# Altium schematic sheet pmic_right.SchDoc(pmic_right)
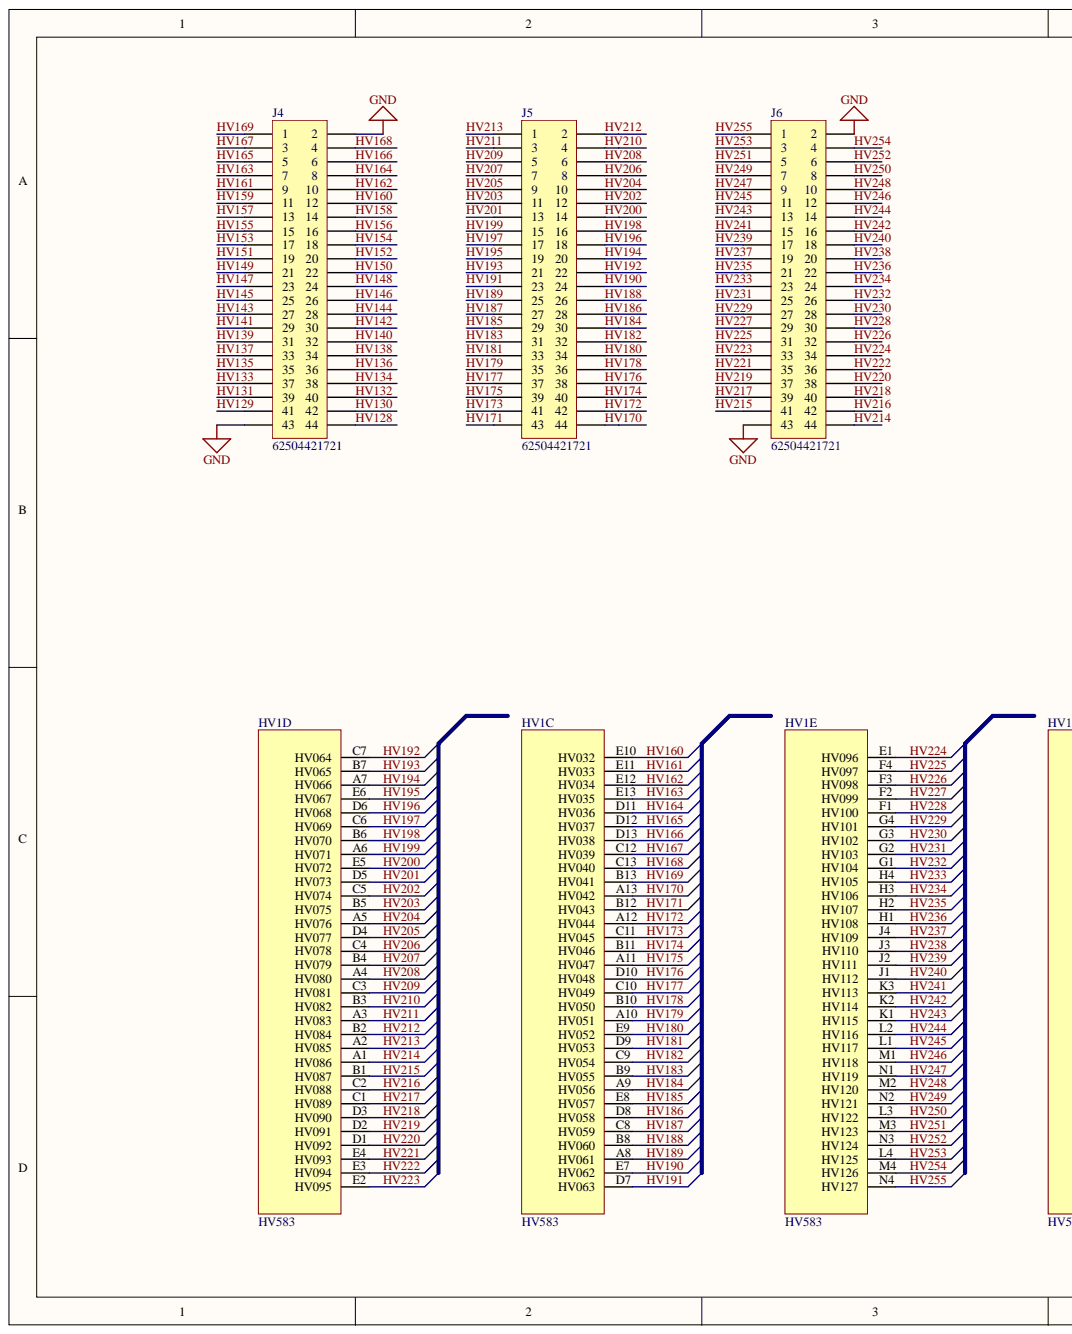
[diagram p0: pmic_right.SchDoc, left]
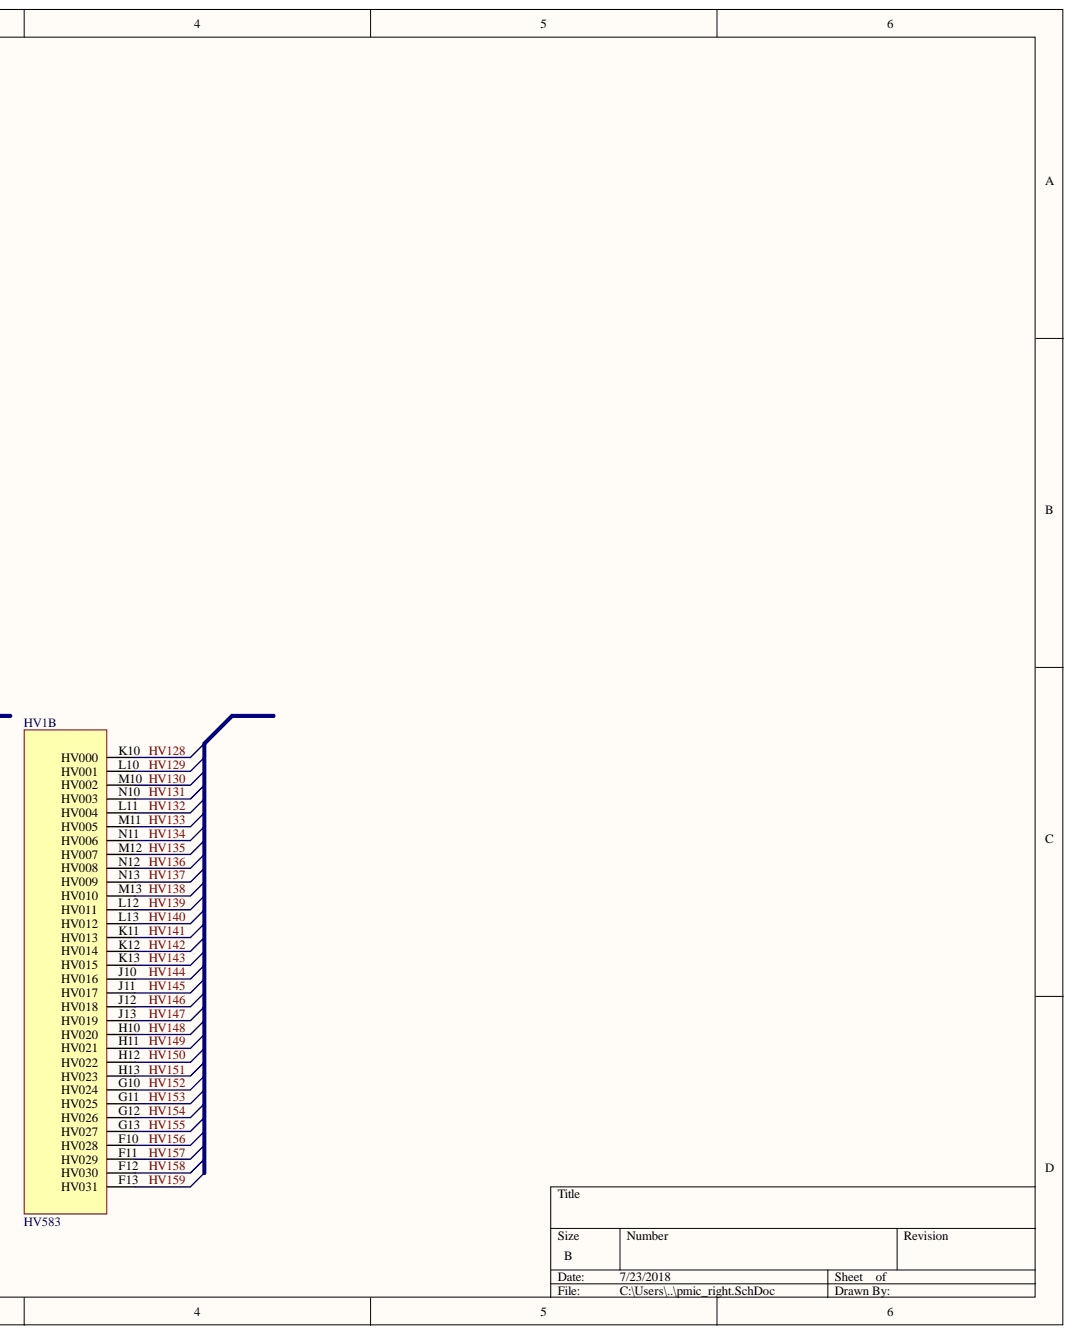
[diagram p1: pmic_right.SchDoc, right]

## Parts
HV1  HV583  [BGA169x13_0.26balls]  (parts B,C,D,E)
J4  62504421721  [62504421721]
J5  62504421721  [62504421721]
J6  62504421721  [62504421721]

## Nets
GND: J4.2 J4.43 J6.2 J6.43
HV128: HV1.K10(HV000) J4.44
HV129: HV1.L10(HV001) J4.41
HV130: HV1.M10(HV002) J4.42
HV131: HV1.N10(HV003) J4.39
HV132: HV1.L11(HV004) J4.40
HV133: HV1.M11(HV005) J4.37
HV134: HV1.N11(HV006) J4.38
HV135: HV1.M12(HV007) J4.35
HV136: HV1.N12(HV008) J4.36
HV137: HV1.N13(HV009) J4.33
HV138: HV1.M13(HV010) J4.34
HV139: HV1.L12(HV011) J4.31
HV140: HV1.L13(HV012) J4.32
HV141: HV1.K11(HV013) J4.29
HV142: HV1.K12(HV014) J4.30
HV143: HV1.K13(HV015) J4.27
HV144: HV1.J10(HV016) J4.28
HV145: HV1.J11(HV017) J4.25
HV146: HV1.J12(HV018) J4.26
HV147: HV1.J13(HV019) J4.23
HV148: HV1.H10(HV020) J4.24
HV149: HV1.H11(HV021) J4.21
HV150: HV1.H12(HV022) J4.22
HV151: HV1.H13(HV023) J4.19
HV152: HV1.G10(HV024) J4.20
HV153: HV1.G11(HV025) J4.17
HV154: HV1.G12(HV026) J4.18
HV155: HV1.G13(HV027) J4.15
HV156: HV1.F10(HV028) J4.16
HV157: HV1.F11(HV029) J4.13
HV158: HV1.F12(HV030) J4.14
HV159: HV1.F13(HV031) J4.11
HV160: HV1.E10(HV032) J4.12
HV161: HV1.E11(HV033) J4.9
HV162: HV1.E12(HV034) J4.10
HV163: HV1.E13(HV035) J4.7
HV164: HV1.D11(HV036) J4.8
HV165: HV1.D12(HV037) J4.5
HV166: HV1.D13(HV038) J4.6
HV167: HV1.C12(HV039) J4.3
HV168: HV1.C13(HV040) J4.4
HV169: HV1.B13(HV041) J4.1
HV170: HV1.A13(HV042) J5.44
HV171: HV1.B12(HV043) J5.43
HV172: HV1.A12(HV044) J5.42
HV173: HV1.C11(HV045) J5.41
HV174: HV1.B11(HV046) J5.40
HV175: HV1.A11(HV047) J5.39
HV176: HV1.D10(HV048) J5.38
HV177: HV1.C10(HV049) J5.37
HV178: HV1.B10(HV050) J5.36
HV179: HV1.A10(HV051) J5.35
HV180: HV1.E9(HV052) J5.34
HV181: HV1.D9(HV053) J5.33
HV182: HV1.C9(HV054) J5.32
HV183: HV1.B9(HV055) J5.31
HV184: HV1.A9(HV056) J5.30
HV185: HV1.E8(HV057) J5.29
HV186: HV1.D8(HV058) J5.28
HV187: HV1.C8(HV059) J5.27
HV188: HV1.B8(HV060) J5.26
HV189: HV1.A8(HV061) J5.25
HV190: HV1.E7(HV062) J5.24
HV191: HV1.D7(HV063) J5.23
HV192: HV1.C7(HV064) J5.22
HV193: HV1.B7(HV065) J5.21
HV194: HV1.A7(HV066) J5.20
HV195: HV1.E6(HV067) J5.19
HV196: HV1.D6(HV068) J5.18
HV197: HV1.C6(HV069) J5.17
HV198: HV1.B6(HV070) J5.16
HV199: HV1.A6(HV071) J5.15
HV200: HV1.E5(HV072) J5.14
HV201: HV1.D5(HV073) J5.13
HV202: HV1.C5(HV074) J5.12
HV203: HV1.B5(HV075) J5.11
HV204: HV1.A5(HV076) J5.10
HV205: HV1.D4(HV077) J5.9
HV206: HV1.C4(HV078) J5.8
HV207: HV1.B4(HV079) J5.7
HV208: HV1.A4(HV080) J5.6
HV209: HV1.C3(HV081) J5.5
HV210: HV1.B3(HV082) J5.4
HV211: HV1.A3(HV083) J5.3
HV212: HV1.B2(HV084) J5.2
HV213: HV1.A2(HV085) J5.1
HV214: HV1.A1(HV086) J6.44
HV215: HV1.B1(HV087) J6.41
HV216: HV1.C2(HV088) J6.42
HV217: HV1.C1(HV089) J6.39
HV218: HV1.D3(HV090) J6.40
HV219: HV1.D2(HV091) J6.37
HV220: HV1.D1(HV092) J6.38
HV221: HV1.E4(HV093) J6.35
HV222: HV1.E3(HV094) J6.36
HV223: HV1.E2(HV095) J6.33
HV224: HV1.E1(HV096) J6.34
HV225: HV1.F4(HV097) J6.31
HV226: HV1.F3(HV098) J6.32
HV227: HV1.F2(HV099) J6.29
HV228: HV1.F1(HV100) J6.30
HV229: HV1.G4(HV101) J6.27
HV230: HV1.G3(HV102) J6.28
HV231: HV1.G2(HV103) J6.25
HV232: HV1.G1(HV104) J6.26
HV233: HV1.H4(HV105) J6.23
HV234: HV1.H3(HV106) J6.24
HV235: HV1.H2(HV107) J6.21
HV236: HV1.H1(HV108) J6.22
HV237: HV1.J4(HV109) J6.19
HV238: HV1.J3(HV110) J6.20
HV239: HV1.J2(HV111) J6.17
HV240: HV1.J1(HV112) J6.18
HV241: HV1.K3(HV113) J6.15
HV242: HV1.K2(HV114) J6.16
HV243: HV1.K1(HV115) J6.13
HV244: HV1.L2(HV116) J6.14
HV245: HV1.L1(HV117) J6.11
HV246: HV1.M1(HV118) J6.12
HV247: HV1.N1(HV119) J6.9
HV248: HV1.M2(HV120) J6.10
HV249: HV1.N2(HV121) J6.7
HV250: HV1.L3(HV122) J6.8
HV251: HV1.M3(HV123) J6.5
HV252: HV1.N3(HV124) J6.6
HV253: HV1.L4(HV125) J6.3
HV254: HV1.M4(HV126) J6.4
HV255: HV1.N4(HV127) J6.1
N00375:
N00376:
N00377:
N00378:

## Library data of the parts
HV1 = HV583: 128bit high voltage shift register; Library Name: Microchip_HV58x.SchLib; Component Kind: Standard
J4-J6 = 62504421721: WR-BHD 2.00mm Male Angled Box Header, 44p; Pin Number: 44; Published Date: June 2017; Pitch: 2.00 mm; Part Number: 62504421721; Library Name: ALTIUM-BHD_2_00_MALE_ANGLED_BOX_HEADER_6250XX21721-rev17a.IntLib; ComponentLink2URL: http://katalog.we-online.de/em/datasheet/6250xx21721.pdf; ComponentLink2Description: Datasheet; ComponentLink1URL: http://www.we-online.de; ComponentLink1Description: Manufacturer; Component Kind: Standard; Publisher: Wurth Elektronik
